# Altium schematic sheet 04_USB3.0_FT60x.SchDoc
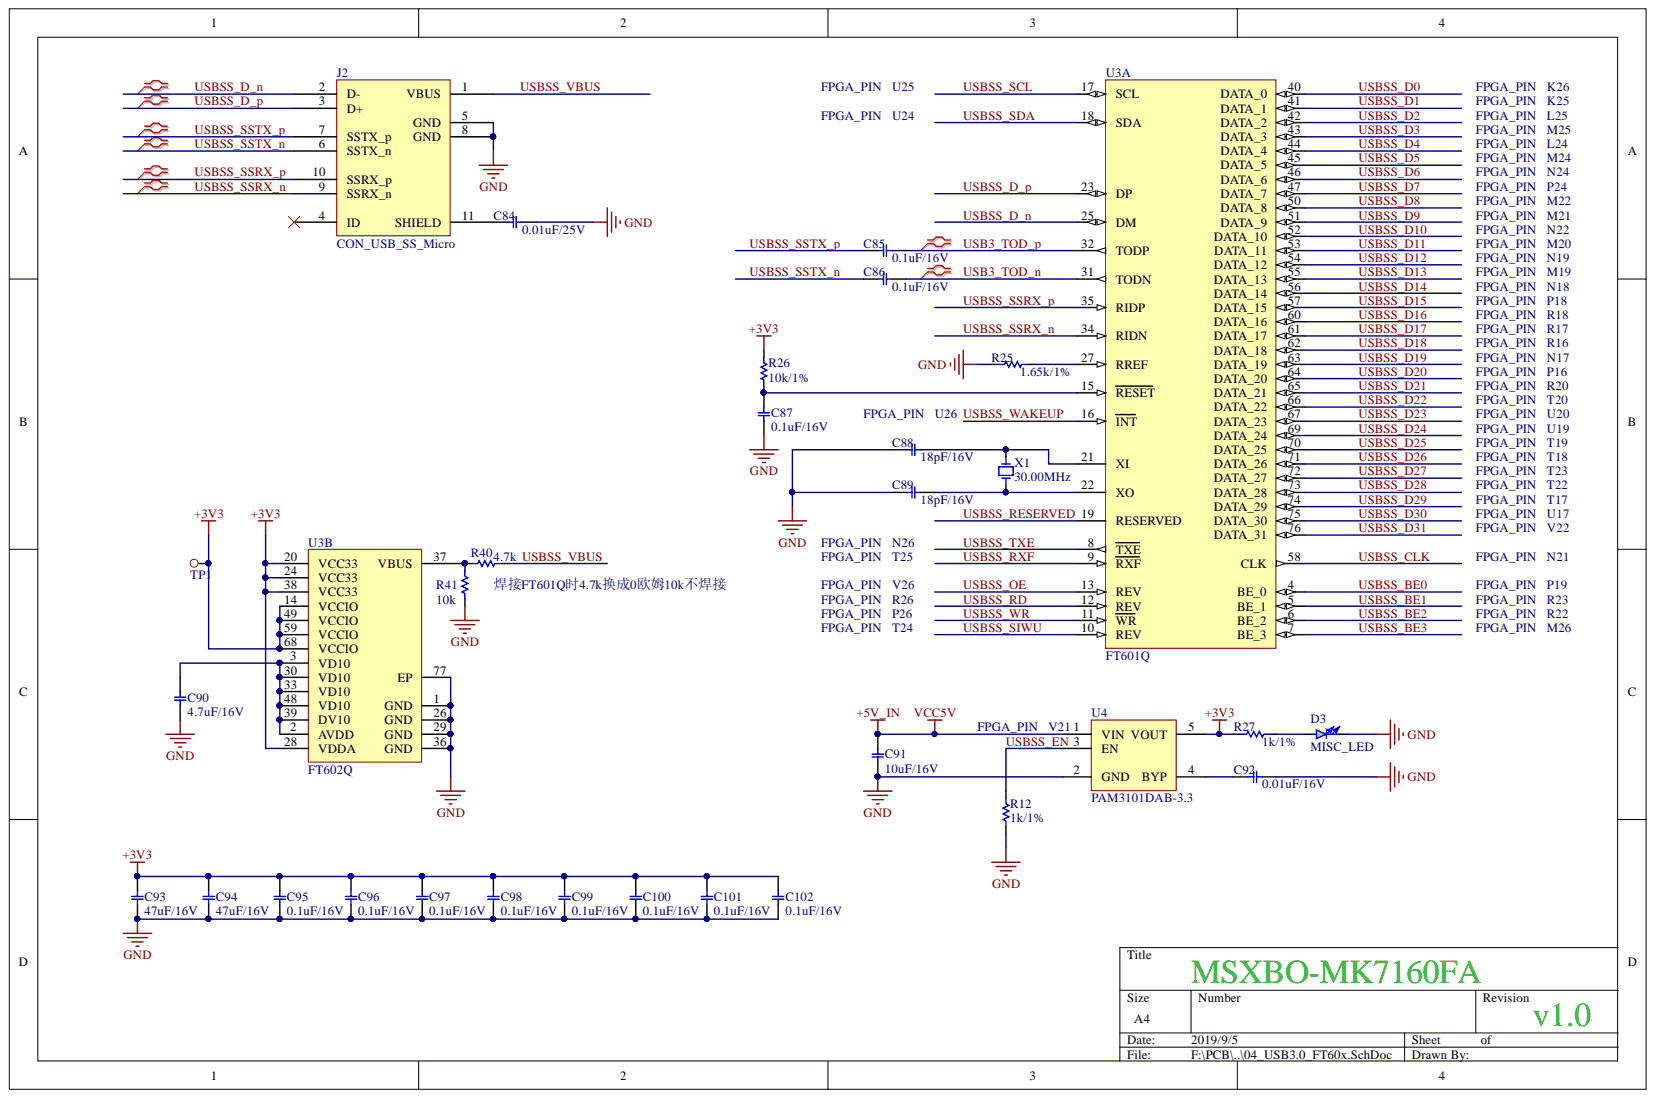
[diagram p0: 04_USB3.0_FT60x.SchDoc]

## Parts
C84  0.01uF/25V  [cap0805]
C85  0.1uF/16V  [cap0603]
C86  0.1uF/16V  [cap0603]
C87  0.1uF/16V  [cap0603]
C88  18pF/16V  [cap0603]
C89  18pF/16V  [cap0603]
C90  4.7uF/16V  [cap0603]
C91  10uF/16V  [cap0805]
C92  0.01uF/16V  [cap0603]
C93  47uF/16V  [cap1210]
C94  47uF/16V  [cap1210]
C95  0.1uF/16V  [cap0603]
C96  0.1uF/16V  [cap0603]
C97  0.1uF/16V  [cap0603]
C98  0.1uF/16V  [cap0603]
C99  0.1uF/16V  [cap0603]
C100  0.1uF/16V  [cap0603]
C101  0.1uF/16V  [cap0603]
C102  0.1uF/16V  [cap0603]
D3  MISC_LED  [LED0805-G]
J2  CON_USB_SS_Micro  [USB3-MICRO]
R12  1k/1%  [res0603]
R25  1.65k/1%  [res0603]
R26  10k/1%  [res0603]
R27  1k/1%  [res0603]
R40  4.7k  [res0603]
R41  10k  [res0603]
TP1  MISC_TP  [Test Point-T]  (value not drawn)
U3  FT601Q  [QFN-76(FT601Q)]  (parts A,B)
U4  PAM3101DAB-3.3  [SOT-23-5]
X1  30.00MHz  [3225]

## Nets
+3V3: C93.2 C94.2 C95.2 C96.2 C97.2 C98.2 C99.2 C100.2 C101.2 C102.2 R26.2 R27.1 TP1.0 U3.14(VCCIO) U3.20(VCC33) U3.24(VCC33) U3.28(VDDA) U3.38(VCC33) U3.49(VCCIO) U3.59(VCCIO) U3.68(VCCIO) U4.5(VOUT)
+5V_IN: C91.2 U4.1(VIN)
GND: C84.2 C87.1 C88.1 C89.1 C90.2 C91.1 C92.1 C93.1 C94.1 C95.1 C96.1 C97.1 C98.1 C99.1 C100.1 C101.1 C102.1 D3.2 J2.5(GND) J2.8(GND) R12.1 R25.1 R41.1 U3.1(GND) U3.26(GND) U3.29(GND) U3.36(GND) U3.77(EP) U4.2(GND)
USB3_TOD_N: C86.2 U3.31(TODN)
USB3_TOD_P: C85.2 U3.32(TODP)
USBSS_BE0: U3.4(BE_0)
USBSS_BE1: U3.5(BE_1)
USBSS_BE2: U3.6(BE_2)
USBSS_BE3: U3.7(BE_3)
USBSS_CLK: U3.58(CLK)
USBSS_D0: U3.40(DATA_0)
USBSS_D1: U3.41(DATA_1)
USBSS_D2: U3.42(DATA_2)
USBSS_D3: U3.43(DATA_3)
USBSS_D4: U3.44(DATA_4)
USBSS_D5: U3.45(DATA_5)
USBSS_D6: U3.46(DATA_6)
USBSS_D7: U3.47(DATA_7)
USBSS_D8: U3.50(DATA_8)
USBSS_D9: U3.51(DATA_9)
USBSS_D10: U3.52(DATA_10)
USBSS_D11: U3.53(DATA_11)
USBSS_D12: U3.54(DATA_12)
USBSS_D13: U3.55(DATA_13)
USBSS_D14: U3.56(DATA_14)
USBSS_D15: U3.57(DATA_15)
USBSS_D16: U3.60(DATA_16)
USBSS_D17: U3.61(DATA_17)
USBSS_D18: U3.62(DATA_18)
USBSS_D19: U3.63(DATA_19)
USBSS_D20: U3.64(DATA_20)
USBSS_D21: U3.65(DATA_21)
USBSS_D22: U3.66(DATA_22)
USBSS_D23: U3.67(DATA_23)
USBSS_D24: U3.69(DATA_24)
USBSS_D25: U3.70(DATA_25)
USBSS_D26: U3.71(DATA_26)
USBSS_D27: U3.72(DATA_27)
USBSS_D28: U3.73(DATA_28)
USBSS_D29: U3.74(DATA_29)
USBSS_D30: U3.75(DATA_30)
USBSS_D31: U3.76(DATA_31)
USBSS_D_N: J2.2(D-) U3.25(DM)
USBSS_D_P: J2.3(D+) U3.23(DP)
USBSS_EN: R12.2 U4.3(EN)
USBSS_OE: U3.13(REV)
USBSS_RD: U3.12(REV)
USBSS_RESERVED: U3.19(RESERVED)
USBSS_RXF: U3.9(RXF)
USBSS_SCL: U3.17(SCL)
USBSS_SDA: U3.18(SDA)
USBSS_SIWU: U3.10(REV)
USBSS_SSRX_N: J2.9(SSRX_n) U3.34(RIDN)
USBSS_SSRX_P: J2.10(SSRX_p) U3.35(RIDP)
USBSS_SSTX_N: C86.1 J2.6(SSTX_n)
USBSS_SSTX_P: C85.1 J2.7(SSTX_p)
USBSS_TXE: U3.8(TXE)
USBSS_VBUS: J2.1(VBUS) R40.1
USBSS_WAKEUP: U3.16(INT)
USBSS_WR: U3.11(WR)
NetC84_1: C84.1 J2.11(SHIELD)
NetC87_2: C87.2 R26.1 U3.15(RESET)
NetC88_2: C88.2 U3.21(XI) X1.3
NetC89_2: C89.2 U3.22(XO) X1.1
NetC90_1: C90.1 U3.2(AVDD) U3.3(VD10) U3.30(VD10) U3.33(VD10) U3.39(DV10) U3.48(VD10)
NetC92_2: C92.2 U4.4(BYP)
NetD3_1: D3.1 R27.2
NetR25_2: R25.2 U3.27(RREF)
NetR40_2: R40.2 R41.2 U3.37(VBUS)
FPGA_PIN:
K25:
K26:
L24:
L25:
M19:
M20:
M21:
M22:
M24:
M25:
M26:
N17:
N18:
N19:
N21:
N22:
N24:
N26:
P16:
P18:
P19:
P24:
P26:
R16:
R17:
R18:
R20:
R22:
R23:
R26:
T17:
T18:
T19:
T20:
T22:
T23:
T24:
T25:
U17:
U19:
U20:
U24:
U25:
U26:
V22:
V26:

Not connected: J2 4(ID)

## Library data of the parts
C84 = CAP_0.01uF/25V: Capacitor; Component Kind: Standard; Library Name: EnHom Frequently Used.SchLib
C85-C90 C92 C95-C102 = CAP_0.1uF/16V: Capacitor; Component Kind: Standard; Library Name: EnHom Frequently Used.SchLib
C91 = CAP_10uF/16V: Capacitor; Component Kind: Standard; Library Name: EnHom Frequently Used.SchLib
C93 C94 = CAP_47uF/16V: Capacitor; Component Kind: Standard; Library Name: EnHom Frequently Used.SchLib
R12 R25-R27 R40 R41 = RES_0/1%: Res; Component Kind: Standard; Library Name: EnHom Frequently Used.SchLib
TP1 = MISC_TP: Test Point; Component Kind: Standard; Library Name: EnHom Frequently Used.SchLib
U3 = IC_IF_FT602Q: 32 Bit USB 3.0 to FIFO Bridge, 5 Gbps, 3 to 3.6 V, -40 to 85 degC, 76-Pin QFN, Pb-Free; Component Kind: Standard; ComponentLink1Description: Manufacturer URL; ComponentLink1URL: http://www.ftdichip.com/; ComponentLink2Description: Datasheet; ComponentLink2URL: http://www.ftdichip.com/Support/Documents/DataSheets/ICs/DS_FT600Q-FT601Q%20IC%20Datasheet.pdf; DatasheetVersion: Rev. 1.02, 02/2016; Mounting Technology: Surface Mount; PackageDescription: 76-Pin Quad Flat No-lead Package, Body 9 x 9 mm, Pitch 0.4 mm, Thermal Pad 5.45 x 5.45 mm; PackageReference: QFN-76; PartId: 1; RoHSCompliant: false; Library Name: EnHom Frequently Used.SchLib
U4 = IC_PWR_PAM3101DAB-3.3: 300mA High PSRR Low Dropout CMOS Linear Regulator; Component Kind: Standard; Library Name: EnHom Frequently Used.SchLib
X1 = CRYSTAL_30.00MHz: Quartz Crystal Resonator; Component Kind: Standard; Library Name: A7_Fun_Library.SchLib
D3 = MISC_LED: Component Kind: Standard; LatestRevisionDate: 17-Jul-2002; LatestRevisionNote: Re-released for DXP Platform.; PackageDocument: Feb-2002; PackageReference: 3.2X1.6X1.1; Published: 8-Jun-2000; Library Name: EnHom Frequently Used.SchLib; Publisher: Altium Limited
J2 = CON_USB_SS_Micro: Component Kind: Standard; Library Name: EnHom Frequently Used.SchLib
